# Altium schematic sheet FPGA_de_cap.SchDoc
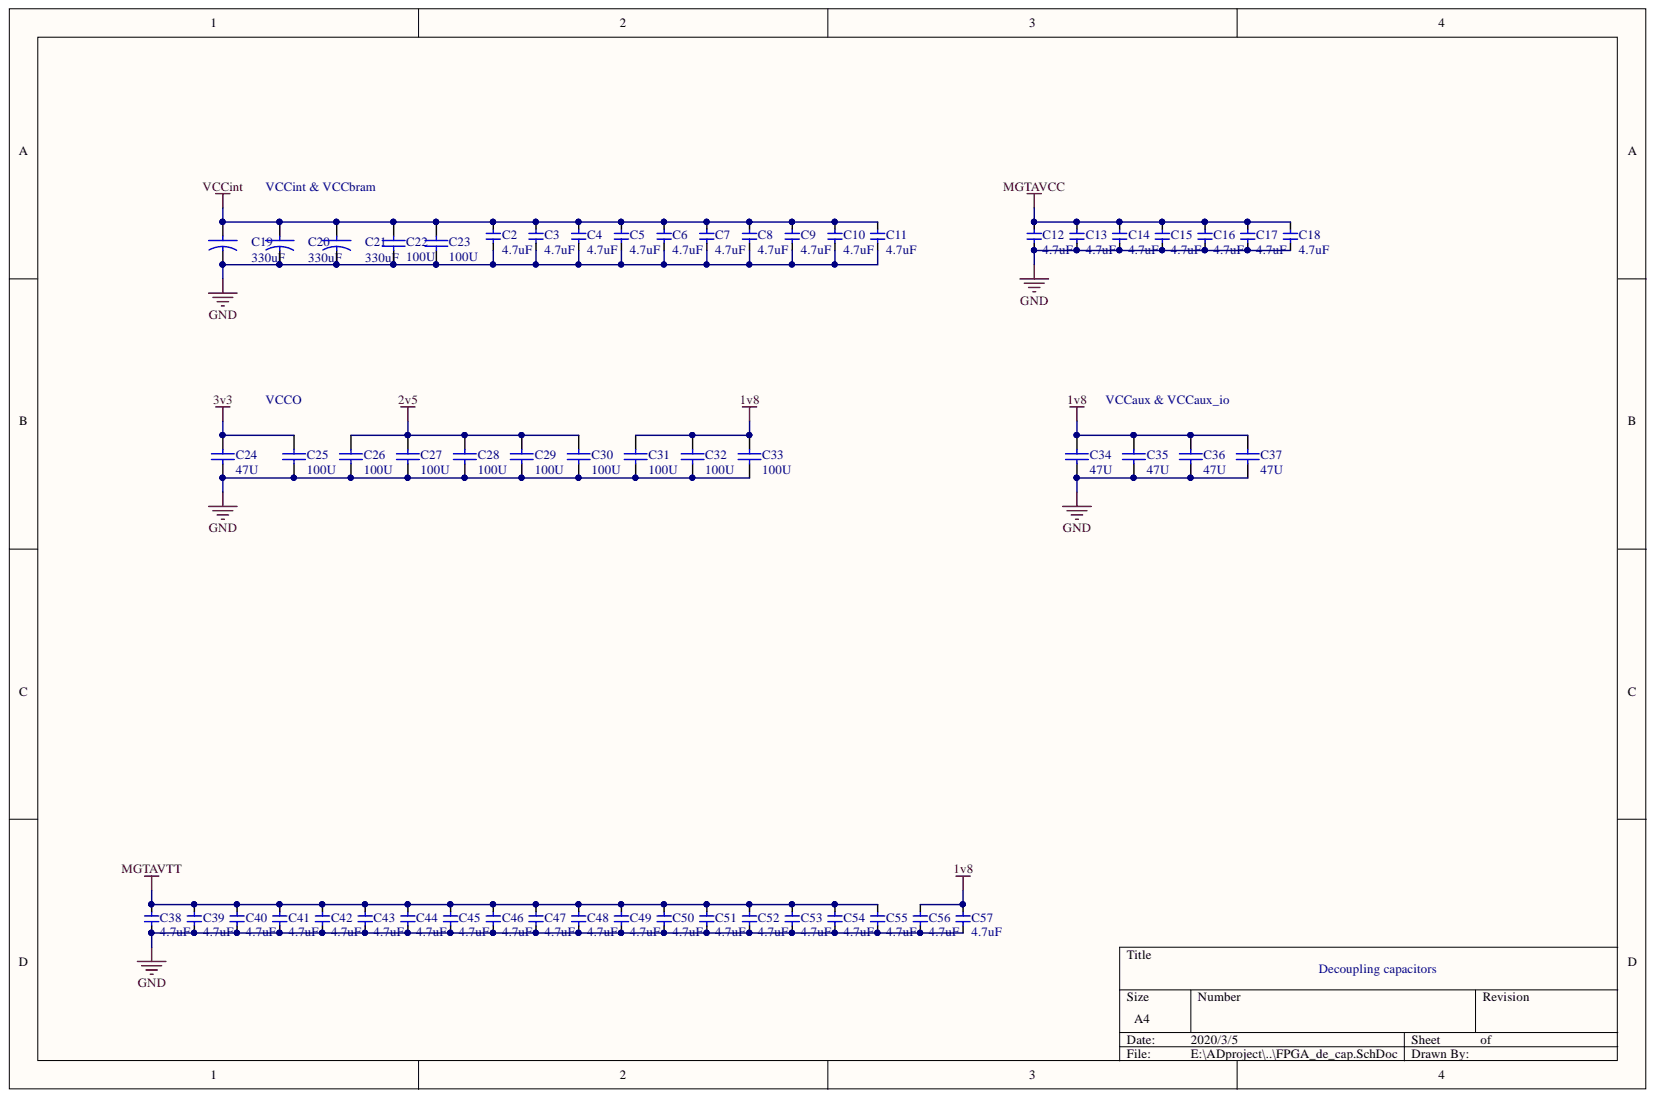
[diagram p0: FPGA_de_cap.SchDoc]

## Parts
C2  value 4.7uF  [0402]  (hidden comment: GRM155R60J475ME87D)
C3  value 4.7uF  [0402]  (hidden comment: GRM155R60J475ME87D)
C4  value 4.7uF  [0402]  (hidden comment: GRM155R60J475ME87D)
C5  value 4.7uF  [0402]  (hidden comment: GRM155R60J475ME87D)
C6  value 4.7uF  [0402]  (hidden comment: GRM155R60J475ME87D)
C7  value 4.7uF  [0402]  (hidden comment: GRM155R60J475ME87D)
C8  value 4.7uF  [0402]  (hidden comment: GRM155R60J475ME87D)
C9  value 4.7uF  [0402]  (hidden comment: GRM155R60J475ME87D)
C10  value 4.7uF  [0402]  (hidden comment: GRM155R60J475ME87D)
C11  value 4.7uF  [0402]  (hidden comment: GRM155R60J475ME87D)
C12  value 4.7uF  [0402]  (hidden comment: GRM155R60J475ME87D)
C13  value 4.7uF  [0402]  (hidden comment: GRM155R60J475ME87D)
C14  value 4.7uF  [0402]  (hidden comment: GRM155R60J475ME87D)
C15  value 4.7uF  [0402]  (hidden comment: GRM155R60J475ME87D)
C16  value 4.7uF  [0402]  (hidden comment: GRM155R60J475ME87D)
C17  value 4.7uF  [0402]  (hidden comment: GRM155R60J475ME87D)
C18  value 4.7uF  [0402]  (hidden comment: GRM155R60J475ME87D)
C19  value 330uF  [cap2917]  (hidden comment: T520V337M2R5ATE025)
C20  value 330uF  [cap2917]  (hidden comment: T520V337M2R5ATE025)
C21  value 330uF  [cap2917]  (hidden comment: T520V337M2R5ATE025)
C22  value 100U  [C1210]  (hidden comment: GRM32ER60J107ME20L)
C23  value 100U  [c1210]  (hidden comment: GRM32ER60J107ME20L)
C24  value 47U  [C1210]  (hidden comment: GRM32ER70J476ME20L)
C25  value 100U  [C1210]  (hidden comment: GRM32ER60J107ME20L)
C26  value 100U  [C1210]  (hidden comment: GRM32ER60J107ME20L)
C27  value 100U  [C1210]  (hidden comment: GRM32ER60J107ME20L)
C28  value 100U  [C1210]  (hidden comment: GRM32ER60J107ME20L)
C29  value 100U  [C1210]  (hidden comment: GRM32ER60J107ME20L)
C30  value 100U  [C1210]  (hidden comment: GRM32ER60J107ME20L)
C31  value 100U  [C1210]  (hidden comment: GRM32ER60J107ME20L)
C32  value 100U  [C1210]  (hidden comment: GRM32ER60J107ME20L)
C33  value 100U  [C1210]  (hidden comment: GRM32ER60J107ME20L)
C34  value 47U  [C1210]  (hidden comment: GRM32ER70J476ME20L)
C35  value 47U  [C1210]  (hidden comment: GRM32ER70J476ME20L)
C36  value 47U  [C1210]  (hidden comment: GRM32ER70J476ME20L)
C37  value 47U  [C1210]  (hidden comment: GRM32ER70J476ME20L)
C38  value 4.7uF  [0402]  (hidden comment: GRM155R60J475ME87D)
C39  value 4.7uF  [0402]  (hidden comment: GRM155R60J475ME87D)
C40  value 4.7uF  [0402]  (hidden comment: GRM155R60J475ME87D)
C41  value 4.7uF  [0402]  (hidden comment: GRM155R60J475ME87D)
C42  value 4.7uF  [0402]  (hidden comment: GRM155R60J475ME87D)
C43  value 4.7uF  [0402]  (hidden comment: GRM155R60J475ME87D)
C44  value 4.7uF  [0402]  (hidden comment: GRM155R60J475ME87D)
C45  value 4.7uF  [0402]  (hidden comment: GRM155R60J475ME87D)
C46  value 4.7uF  [0402]  (hidden comment: GRM155R60J475ME87D)
C47  value 4.7uF  [0402]  (hidden comment: GRM155R60J475ME87D)
C48  value 4.7uF  [0402]  (hidden comment: GRM155R60J475ME87D)
C49  value 4.7uF  [0402]  (hidden comment: GRM155R60J475ME87D)
C50  value 4.7uF  [0402]  (hidden comment: GRM155R60J475ME87D)
C51  value 4.7uF  [0402]  (hidden comment: GRM155R60J475ME87D)
C52  value 4.7uF  [0402]  (hidden comment: GRM155R60J475ME87D)
C53  value 4.7uF  [0402]  (hidden comment: GRM155R60J475ME87D)
C54  value 4.7uF  [0402]  (hidden comment: GRM155R60J475ME87D)
C55  value 4.7uF  [0402]  (hidden comment: GRM155R60J475ME87D)
C56  value 4.7uF  [0402]  (hidden comment: GRM155R60J475ME87D)
C57  value 4.7uF  [0402]  (hidden comment: GRM155R60J475ME87D)

## Nets
1v8: C31.2 C32.2 C33.2 C34.2 C35.2 C36.2 C37.2 C56.2 C57.2
2v5: C26.2 C27.2 C28.2 C29.2 C30.2
3v3: C24.2 C25.2
GND: C2.1 C3.1 C4.1 C5.1 C6.1 C7.1 C8.1 C9.1 C10.1 C11.1 C12.1 C13.1 C14.1 C15.1 C16.1 C17.1 C18.1 C19.2 C20.2 C21.2 C22.1 C23.1 C24.1 C25.1 C26.1 C27.1 C28.1 C29.1 C30.1 C31.1 C32.1 C33.1 C34.1 C35.1 C36.1 C37.1 C38.1 C39.1 C40.1 C41.1 C42.1 C43.1 C44.1 C45.1 C46.1 C47.1 C48.1 C49.1 C50.1 C51.1 C52.1 C53.1 C54.1 C55.1 C56.1 C57.1
MGTAVCC: C12.2 C13.2 C14.2 C15.2 C16.2 C17.2 C18.2
MGTAVTT: C38.2 C39.2 C40.2 C41.2 C42.2 C43.2 C44.2 C45.2 C46.2 C47.2 C48.2 C49.2 C50.2 C51.2 C52.2 C53.2 C54.2 C55.2
VCCint: C2.2 C3.2 C4.2 C5.2 C6.2 C7.2 C8.2 C9.2 C10.2 C11.2 C19.1 C20.1 C21.1 C22.2 C23.2

## Library data of the parts
C2-C18 C38-C57 = CapSMT: Capacitor (Surface Mount); Component Kind: Standard; Signal Integrity: Cap; Simulation: CAP; Publisher: Altium Limited
  C2-C11 C38-C57: Library Name: general.SchLib
  C12-C18: Library Name: LS1_full_size.SCHLIB
C19-C21 = Cap_pol: Component Kind: Standard; Library Name: general.SchLib
C22-C37 = Cap Semi: Capacitor (Semiconductor SIM Model); Component Kind: Standard; Library Name: general.SchLib; PackageReference: C1206; Signal Integrity: Cap Semi; Simulation: CAP; Publisher: Altium Limited
